# Altium schematic sheet WristCoils.SchDoc(WristCoils)
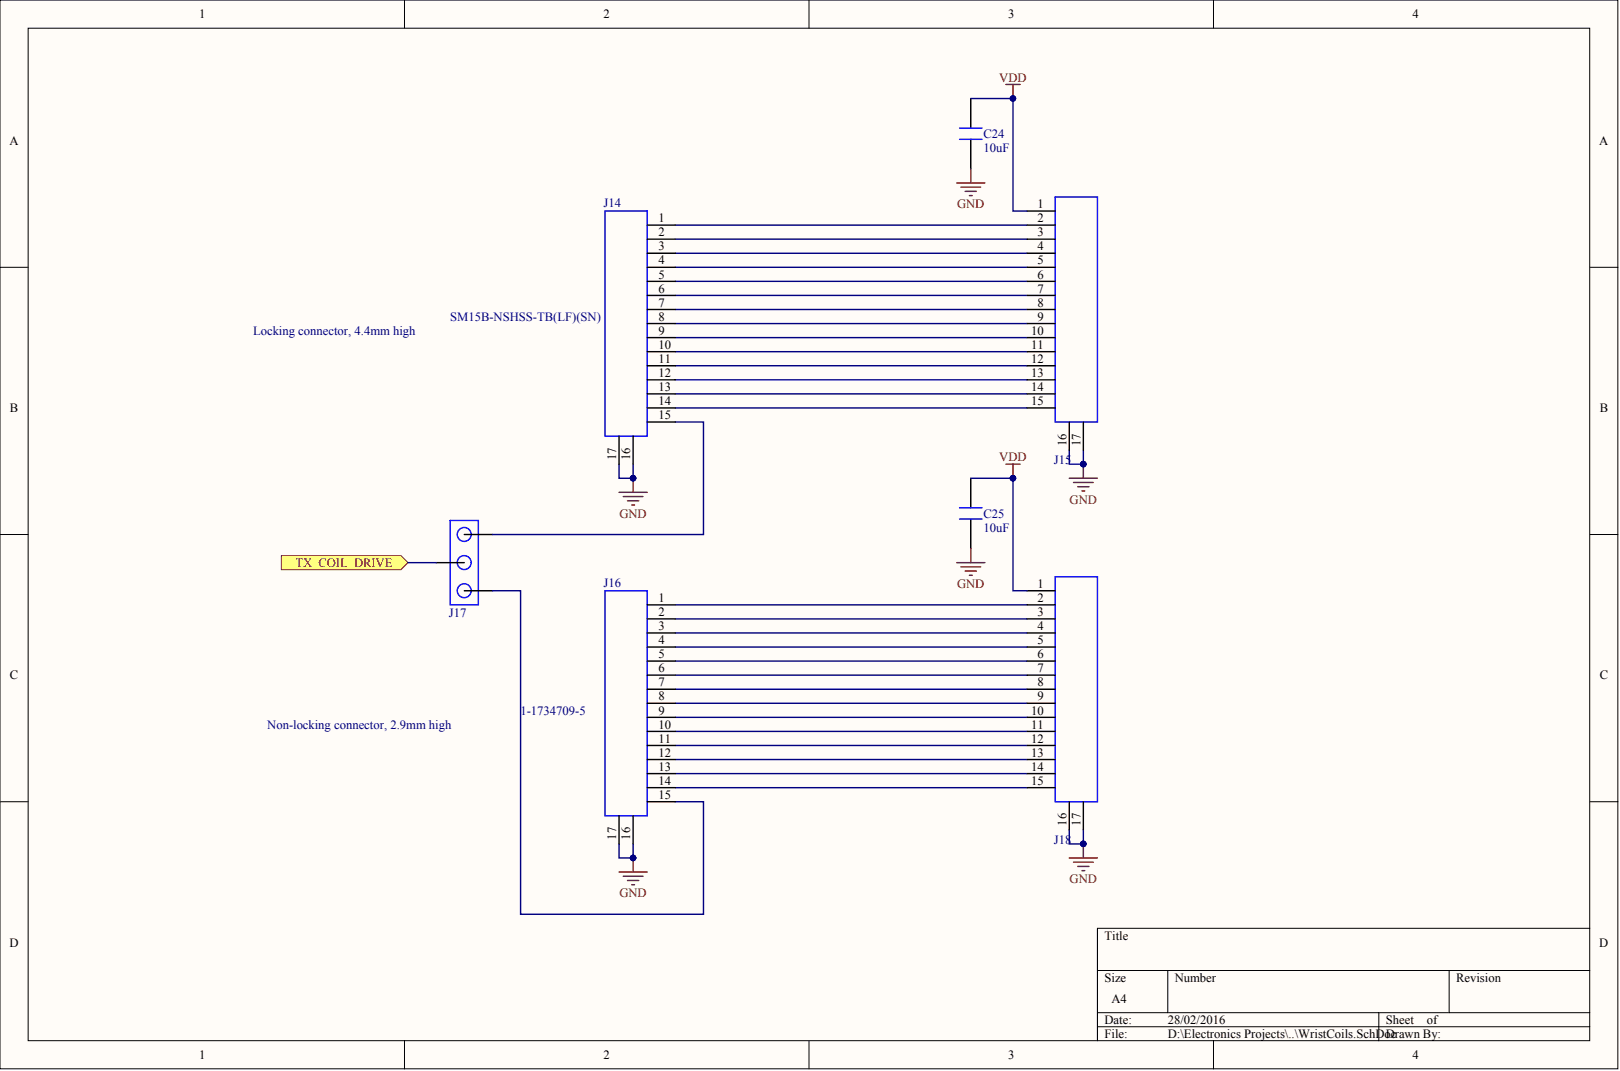
[diagram p0: WristCoils.SchDoc]

## Parts
C24  Capacitance: 10uF  (hidden comment: CAP_0603_10uF)
C25  Capacitance: 10uF  (hidden comment: CAP_0603_10uF)
J14  Part Number: SM15B-NSHSS-TB(LF)(SN)  (hidden comment: CONN_15PIN_HEADER_SM15B-NSHSS-TB)
J15  CONN_15PAD_STRIP  (value not drawn)
J16  Part Number: 1-1734709-5  (hidden comment: CONN_15PIN_HEADER_1-1734709-5)
J17  CONN_3PIN_HEADER  (value not drawn)
J18  CONN_15PAD_STRIP  (value not drawn)

## Ports
TX_COIL_DRIVE

## Nets
GND: C24.1 C25.1 J14.16 J14.17 J15.16 J15.17 J16.16 J16.17 J18.16 J18.17
VDD: C24.2 C25.2 J15.1 J18.1
TX_COIL_DRIVE: J17.2 ; port TX_COIL_DRIVE
NetJ14_1: J14.1 J15.2
NetJ14_2: J14.2 J15.3
NetJ14_3: J14.3 J15.4
NetJ14_4: J14.4 J15.5
NetJ14_5: J14.5 J15.6
NetJ14_6: J14.6 J15.7
NetJ14_7: J14.7 J15.8
NetJ14_8: J14.8 J15.9
NetJ14_9: J14.9 J15.10
NetJ14_10: J14.10 J15.11
NetJ14_11: J14.11 J15.12
NetJ14_12: J14.12 J15.13
NetJ14_13: J14.13 J15.14
NetJ14_14: J14.14 J15.15
NetJ14_15: J14.15 J17.1
NetJ16_1: J16.1 J18.2
NetJ16_2: J16.2 J18.3
NetJ16_3: J16.3 J18.4
NetJ16_4: J16.4 J18.5
NetJ16_5: J16.5 J18.6
NetJ16_6: J16.6 J18.7
NetJ16_7: J16.7 J18.8
NetJ16_8: J16.8 J18.9
NetJ16_9: J16.9 J18.10
NetJ16_10: J16.10 J18.11
NetJ16_11: J16.11 J18.12
NetJ16_12: J16.12 J18.13
NetJ16_13: J16.13 J18.14
NetJ16_14: J16.14 J18.15
NetJ16_15: J16.15 J17.3

## Library data of the parts
C24 C25 = (no symbol name): Manufacturer: Samsung; Part Number: CL10A106MQ8NNNC; Tolerance: 20%; Voltage: 6.3V
J14 = (no symbol name): Manufacturer: JST
J16 = (no symbol name): Manufacturer: TE Connectivity
J17 = (no symbol name): Manufacturer: 3M; Part Number: 951103-8622-AR
